# Altium schematic sheet PM.SchDoc(PM)
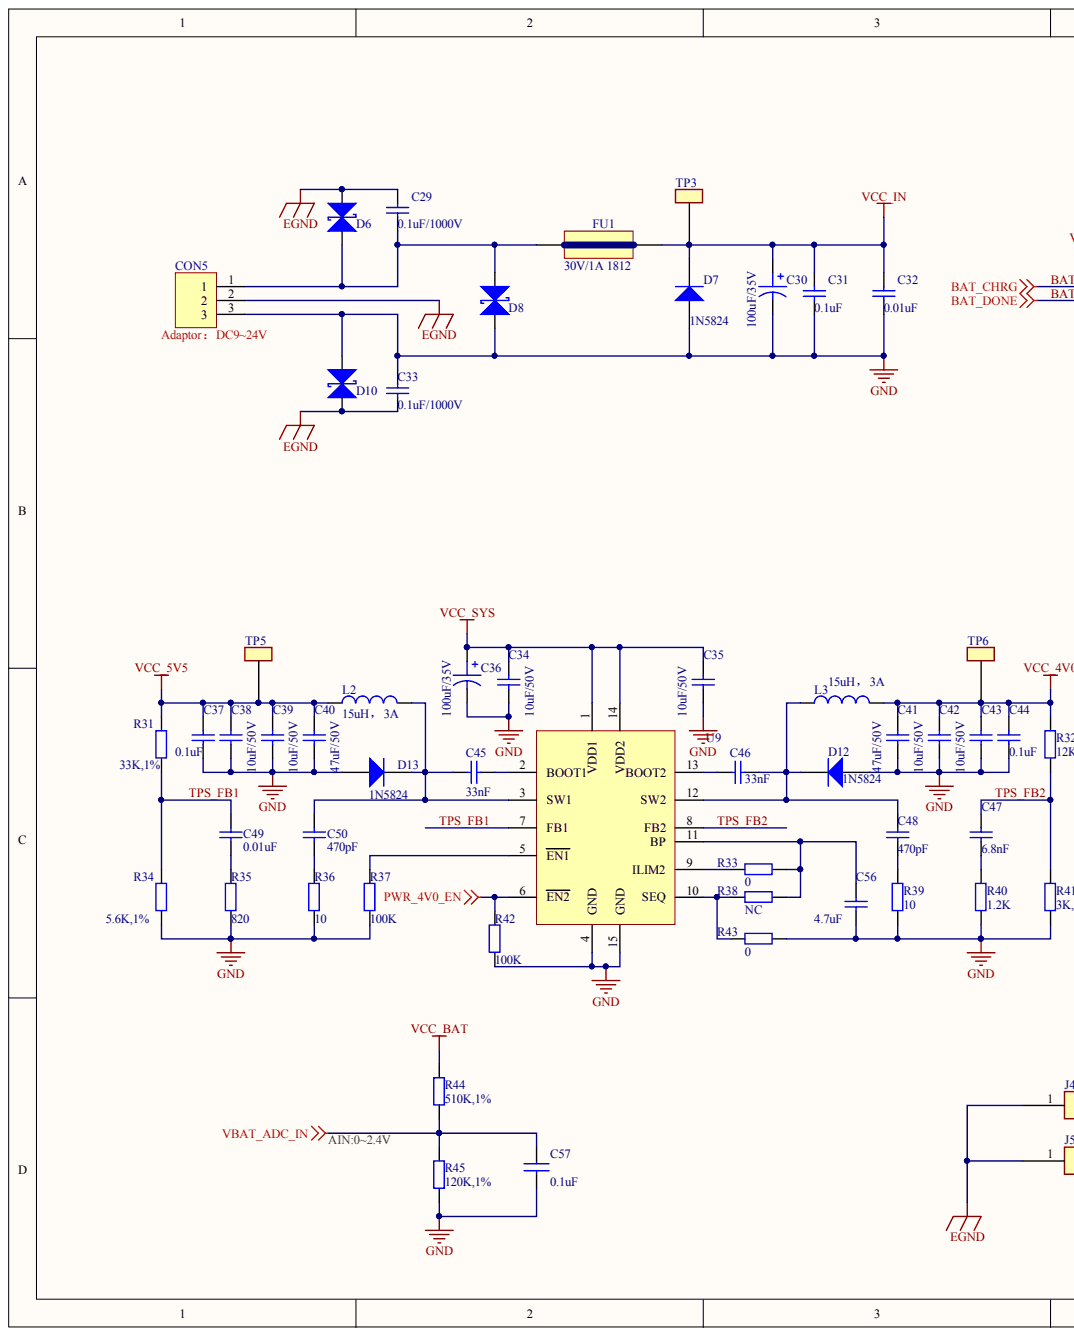
[diagram p0: PM.SchDoc, left]
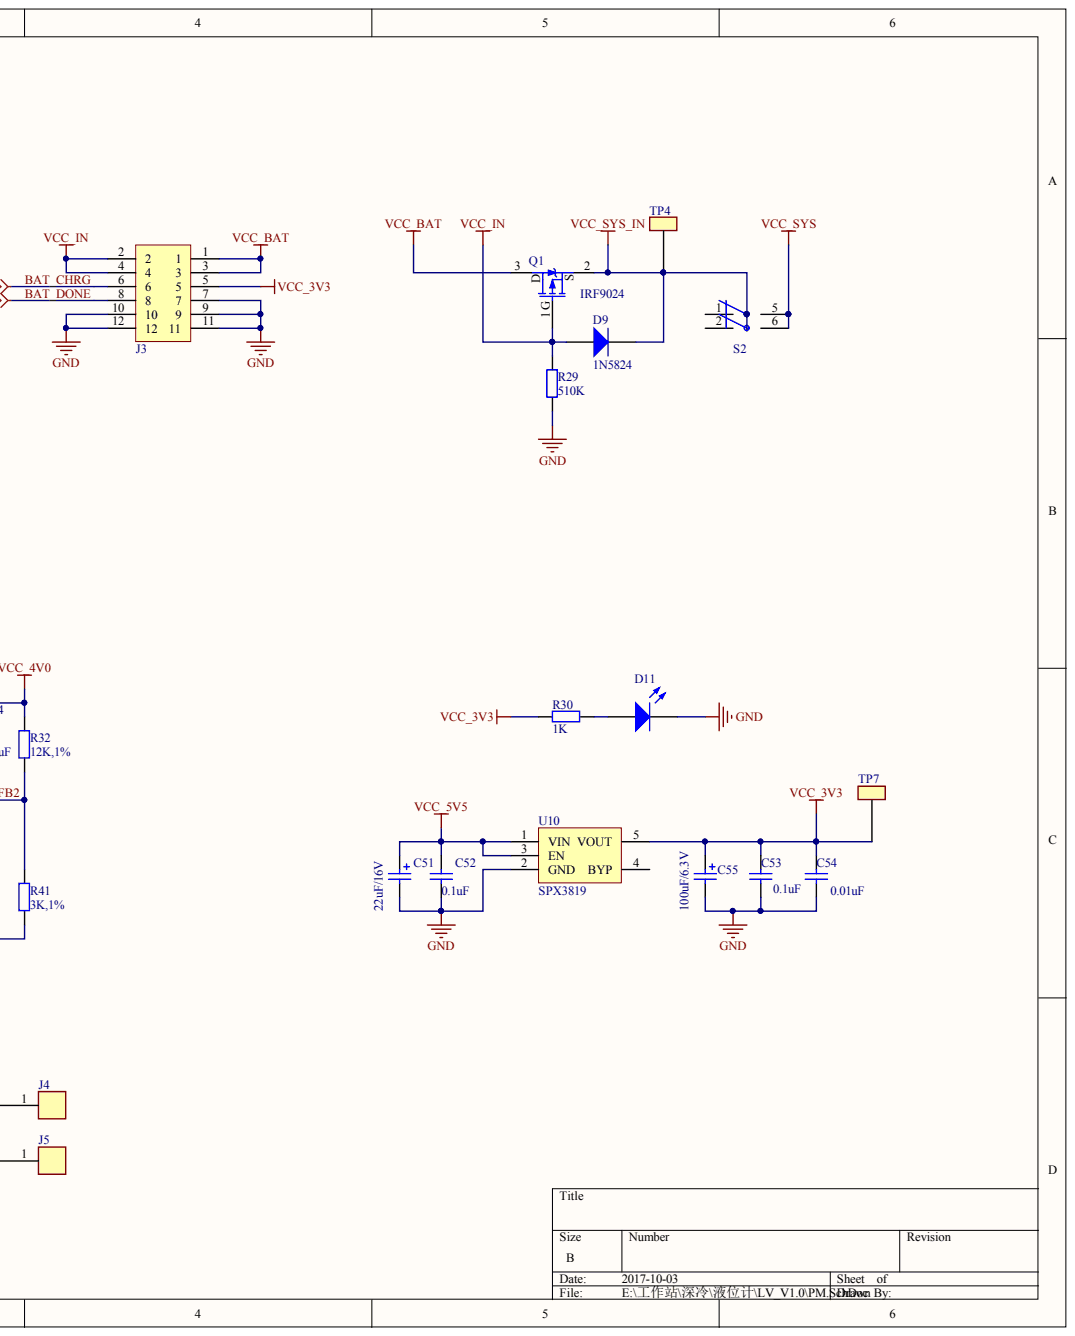
[diagram p1: PM.SchDoc, right]

## Parts
C29  value 0.1uF/1000V  [0603C]  (hidden comment: Capacitor)
C30  100uF/35V  [CAP6X7]
C31  value 0.1uF  [0603C]  (hidden comment: Capacitor)
C32  value 0.01uF  [0603C]  (hidden comment: Capacitor)
C33  value 0.1uF/1000V  [0603C]  (hidden comment: Capacitor)
C34  value 10uF/50V  [0805C]  (hidden comment: Capacitor)
C35  value 10uF/50V  [0805C]  (hidden comment: Capacitor)
C36  100uF/35V  [CAP6X7]
C37  value 0.1uF  [0603C]  (hidden comment: Capacitor)
C38  value 10uF/50V  [0805C]  (hidden comment: Capacitor)
C39  value 10uF/50V  [0805C]  (hidden comment: Capacitor)
C40  value 47uF/50V  [1206]  (hidden comment: Capacitor)
C41  value 47uF/50V  [1206]  (hidden comment: Capacitor)
C42  value 10uF/50V  [0805C]  (hidden comment: Capacitor)
C43  value 10uF/50V  [0805C]  (hidden comment: Capacitor)
C44  value 0.1uF  [0603C]  (hidden comment: Capacitor)
C45  value 33nF  [0603C]  (hidden comment: Capacitor)
C46  value 33nF  [0603C]  (hidden comment: Capacitor)
C47  value 6.8nF  [0603C]  (hidden comment: Capacitor)
C48  value 470pF  [0603C]  (hidden comment: Capacitor)
C49  value 0.01uF  [0603C]  (hidden comment: Capacitor)
C50  value 470pF  [0603C]  (hidden comment: Capacitor)
C51  value 22uF/16V  [EIA1206]
C52  value 0.1uF  [0603C]  (hidden comment: Capacitor)
C53  value 0.1uF  [0603C]  (hidden comment: Capacitor)
C54  value 0.01uF  [0603C]  (hidden comment: Capacitor)
C55  value 100uF/6.3V  [B-3528]
C56  value 4.7uF  [0603C]  (hidden comment: Capacitor)
C57  value 0.1uF  [0603C]  (hidden comment: CAP NP)
CON5  CONNECTOR_3PIN  [XH2.54-3]  (value not drawn)
D6  D_TVS  [DO214AA(SMB)]  (value not drawn)
D7  value 1N5824  [DO214AC(SMA)]  (hidden comment: Diode)
D8  D_TVS  [DO214AA(SMB)]  (value not drawn)
D9  value 1N5824  [DO214AC(SMA)]  (hidden comment: Diode)
D10  D_TVS  [DO214AA(SMB)]  (value not drawn)
D11  LED0  [LED0805]  (value not drawn)
D12  value 1N5824  [DO214AC(SMA)]  (hidden comment: Diode)
D13  value 1N5824  [DO214AC(SMA)]  (hidden comment: Diode)
FU1  value 30V/1A 1812  [1812]  (hidden comment: FUSE)
J3  Header 6x2  [SOP2.0-2x6]  (value not drawn)
J4  CON1  [MHT_4mm]  (value not drawn)
J5  CON1  [MHT_4mm]  (value not drawn)
L2  value 15uH，3A  [0630]  (hidden comment: Inductor)
L3  value 15uH，3A  [0630]  (hidden comment: Inductor)
Q1  value IRF9024  [DPAK228P]  (hidden comment: P-CH MOS)
R29  value 510K  [L0603]  (hidden comment: Resistor)
R30  value 1K  [L0603]  (hidden comment: Resistor)
R31  value 33K,1%  [L0603]  (hidden comment: Resistor)
R32  value 12K,1%  [L0603]  (hidden comment: Resistor)
R33  value 0  [L0603]  (hidden comment: Resistor)
R34  value 5.6K,1%  [L0603]  (hidden comment: Resistor)
R35  value 820  [L0603]  (hidden comment: Resistor)
R36  value 10  [L0603]  (hidden comment: Resistor)
R37  value 100K  [L0603]  (hidden comment: Resistor)
R38  value NC  [L0603]  (hidden comment: Resistor)
R39  value 10  [L0603]  (hidden comment: Resistor)
R40  value 1.2K  [L0603]  (hidden comment: Resistor)
R41  value 3K,1%  [L0603]  (hidden comment: Resistor)
R42  value 100K  [L0603]  (hidden comment: Resistor)
R43  value 0  [L0603]  (hidden comment: Resistor)
R44  value 510K,1%  [L0603]  (hidden comment: Resistor)
R45  value 120K,1%  [L0603]  (hidden comment: Resistor)
S2  MSS22D18  [MSS22D18]  (value not drawn)
TP3  TEST POINT_0  [TP]  (value not drawn)
TP4  TEST POINT_0  [TP]  (value not drawn)
TP5  TEST POINT_0  [TP]  (value not drawn)
TP6  TEST POINT_0  [TP]  (value not drawn)
TP7  TEST POINT_0  [TP]  (value not drawn)
U9  TPS54386  [R-PDSO-G14]  (value not drawn)
U10  SPX3819  [SOT23-5]

## Nets
EGND: C29.1 C33.2 CON5.2 D6.1 D10.2 J4.1 J5.1
GND: C30.2 C31.2 C32.2 C33.1 C34.2 C35.2 C36.2 C37.2 C38.2 C39.2 C40.2 C41.2 C42.2 C43.2 C44.2 C51.2 C52.2 C53.2 C54.2 C55.2 C56.2 C57.2 CON5.3 D7.1 D8.2 D10.1 D11.2 D12.1 D13.1 J3.7 J3.9 J3.10 J3.11 J3.12 R29.2 R34.1 R35.1 R36.1 R37.1 R39.1 R40.1 R41.1 R42.1 R43.1 R45.2 U9.4(GND) U9.15(GND) U10.2(GND)
PWR_4V0_EN: R42.2 U9.6(EN2)
VBAT_ADC_IN: C57.1 R44.2 R45.1
VCC_3V3: C53.1 C54.1 C55.1 J3.5 R30.2 TP7.1 U10.5(VOUT)
VCC_4V0: C41.1 C42.1 C43.1 C44.1 L3.2 R32.2 TP6.1
VCC_5V5: C37.1 C38.1 C39.1 C40.1 C51.1 C52.1 L2.2 R31.2 TP5.1 U10.1(VIN) U10.3(EN)
VCC_BAT: J3.1 J3.3 Q1.3 R44.1
VCC_IN: C30.1 C31.1 C32.1 D7.2 D9.1 FU1.1 J3.2 J3.4 Q1.1 R29.1 TP3.1
VCC_SYS: C34.1 C35.1 C36.1 S2.5 S2.6 U9.1(VDD1) U9.14(VDD2)
VCC_SYS_IN: D9.2 Q1.2 S2.3 S2.4 TP4.1
BAT_CHRG: J3.6
BAT_DONE: J3.8
TPS_FB1: C49.1 R31.1 R34.2 U9.7(FB1)
TPS_FB2: C47.1 R32.1 R41.2 U9.8(FB2)
NetC29_2: C29.2 CON5.1 D6.2 D8.1 FU1.2
NetC45_1: C45.1 U9.2(BOOT1)
NetC45_2: C45.2 C50.1 D13.2 L2.1 U9.3(SW1)
NetC46_1: C46.1 U9.13(BOOT2)
NetC46_2: C46.2 C48.1 D12.2 L3.1 U9.12(SW2)
NetC47_2: C47.2 R40.2
NetC48_2: C48.2 R39.2
NetC49_2: C49.2 R35.2
NetC50_2: C50.2 R36.2
NetC56_1: C56.1 R33.1 R38.1 U9.11(BP)
NetD11_1: D11.1 R30.1
NetR33_2: R33.2 U9.9(ILIM2)
NetR37_2: R37.2 U9.5(EN1)
NetR38_2: R38.2 R43.2 U9.10(SEQ)

Not connected: S2 1 2; U10 4(BYP)

## Library data of the parts
C29 C31-C35 C37-C39 C41-C50 C52-C54 C56 = Cap: Component Kind: Standard; Description: Capacitor; LatestRevisionDate: 17-Jul-2002; LatestRevisionNote: Re-released for DXP Platform.; PackageDescription: Radial Cap, Thru-Hole; 2 Leads; 0.3 in Pin Spacing; PackageReference: RAD-0.3; Published: 8-Jun-2000; Signal Integrity: Cap; Simulation: CAP; Publisher: Altium Limited
  C29 C31-C35 C37-C39 C41-C44 C54: Library Name: Miscellaneous Devices.IntLib
  C45-C50 C52 C53 C56: Library Name: Lib1.SCHLIB
C30 C36 = CAPACITOR POL_3: Component Kind: Standard; Library Name: SENSOR_BOARD_Library.SchLib; Value: 100uF/35V
C40 = Cap: Value: 47uF/50V; Component Kind: Standard; Description: Capacitor; LatestRevisionDate: 17-Jul-2002; LatestRevisionNote: Re-released for DXP Platform.; Library Name: Miscellaneous Devices.IntLib; PackageDescription: Radial Cap, Thru-Hole; 2 Leads; 0.3 in Pin Spacing; PackageReference: RAD-0.3; Published: 8-Jun-2000; Signal Integrity: Cap; Simulation: CAP; Publisher: Altium Limited
C51 C55 = Cap pol: Component Kind: Standard; Library Name: LNG.SCHLIB
D6 D10 = D_TVS: Value: SMBJ26CA; Component Kind: Standard; Library Name: MyLib.SCHLIB
D8 = D_TVS: Value: SMCJ26CA; Component Kind: Standard; Library Name: MyLib.SCHLIB
D11 = LED0805: Typical INFRARED GaAs LED; Value: LED_5mm_RED; Component Kind: Standard; LatestRevisionDate: 08-Jul-2005; LatestRevisionNote: Mech Layer 1 removed.; PackageDescription: LED; 2 Leads; PackageReference: LED-0; Published: 8-Jun-2000; Library Name: 简配版生物罐.SCHLIB; Publisher: Altium Limited
J3 = Header 6x2: Value: 2.0间距贴片双排针; Component Kind: Standard; Library Name: MyLib.SCHLIB
L2 L3 = Inductor: Value: 15uH，3A; Component Kind: Standard; Library Name: LNG.SCHLIB
R29-R45 = Res: Component Kind: Standard; Library Name: Lib1.SCHLIB
U9 = TPS54386: Value: TPS54386-Q1; Component Kind: Standard; Library Name: MyLib.SCHLIB
C57 = CAP NP: Component Kind: Standard; Library Name: MAINBOARD_Library.SchLib
CON5 = CONNECTOR_3PIN: Component Kind: Standard; Library Name: MyLib.SCHLIB
D7 D9 D12 D13 = Diode: Component Kind: Standard
  D7 D9: Library Name: zhileng.SchLib
  D12 D13: Library Name: LNG.SCHLIB
FU1 = FUSE: Component Kind: Standard; Library Name: LNG.SCHLIB
J4 J5 = CON1: Component Kind: Standard; Library Name: MAINBOARD_Library.SchLib
Q1 = P-CH MOS: Component Kind: Standard; Library Name: LNG.SCHLIB
S2 = MSS22D18: Component Kind: Standard; Library Name: MyLib.SCHLIB
TP3-TP7 = TEST POINT_0: Component Kind: Standard; Library Name: MAINBOARD_Library.SchLib
U10 = SPX3819: Component Kind: Standard; Value: SPX3819; Library Name: zhileng.SCHLIB
